# Altium schematic sheet FPGA_MISC.SchDoc(FPGA_MISC)
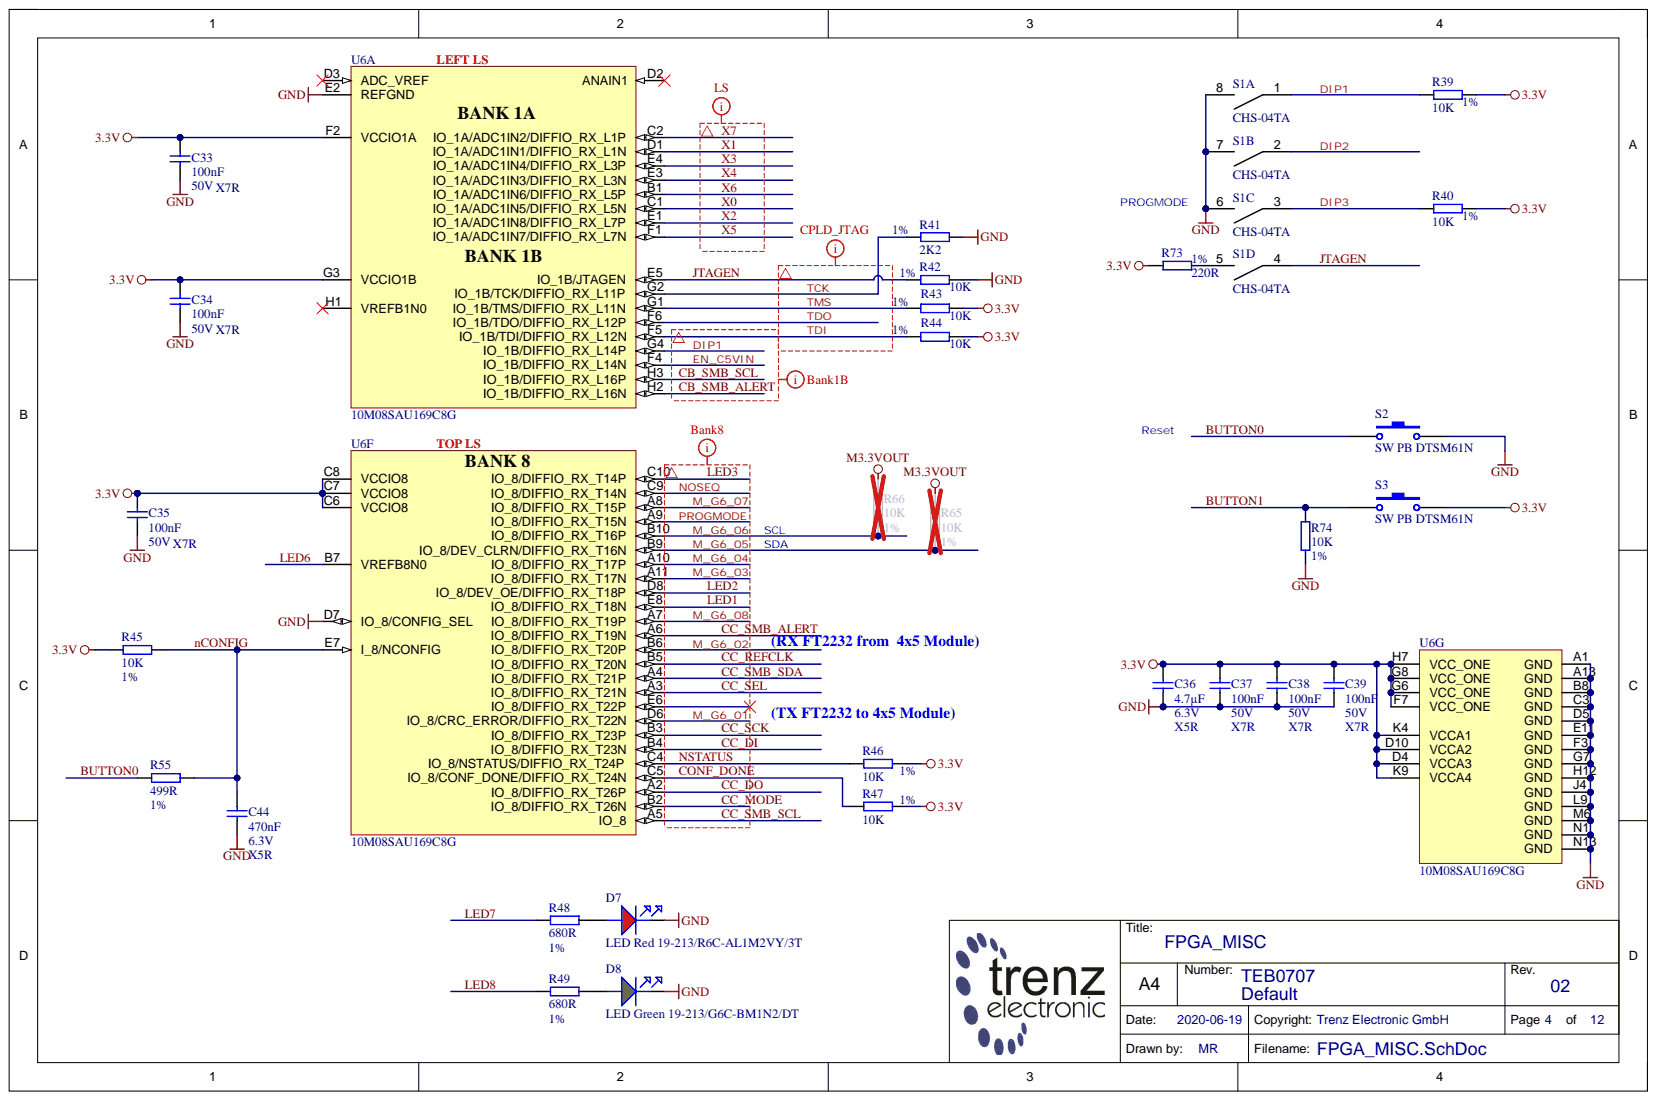
[diagram p0: FPGA_MISC.SchDoc]

## Parts
C33  100nF  Voltage: 50V  [CAPC1005X55N]
C34  100nF  Voltage: 50V  [CAPC1005X55N]
C35  100nF  Voltage: 50V  [CAPC1005X55N]
C36  4.7µF  Technology: X5R  Voltage: 6.3V  [CAPC1005X60N]
C37  100nF  Technology: X7R  Voltage: 50V  [CAPC1005X55N]
C38  100nF  Technology: X7R  Voltage: 50V  [CAPC1005X55N]
C39  100nF  Technology: X7R  Voltage: 50V  [CAPC1005X55N]
C44  470nF  Technology: X5R  Voltage: 6.3V  [CAPC1005X55N]
D7  LED Red 19-213/R6C-AL1M2VY/3T  Database Table Name: LED  H-ART: 19-213/R6C-AL1M2VY/3T  [LEDC1608X55N_RED]
D8  LED Green 19-213/G6C-BM1N2/DT  Database Table Name: LED  H-ART: 19-213/G6C-BM1N2/DT  [LEDC1608X55N_GREEN]
R39  10K  Tolerance: 1%  [RESC1005X40N]
R40  10K  Tolerance: 1%  [RESC1005X40N]
R41  2K2  Tolerance: 1%  [RESC1005X40N]
R42  10K  Tolerance: 1%  [RESC1005X40N]
R43  10K  Tolerance: 1%  [RESC1005X40N]
R44  10K  Tolerance: 1%  [RESC1005X40N]
R45  10K  Tolerance: 1%  [RESC1005X40N]
R46  10K  Tolerance: 1%  [RESC1005X40N]
R47  10K  Tolerance: 1%  [RESC1005X40N]
R48  680R  Tolerance: 1%  [RESC1005X40N]
R49  680R  Tolerance: 1%  [RESC1005X40N]
R55  499R  Tolerance: 1%  [RESC1005X40N]
R65  10K  Tolerance: 1%  [RESC1005X40N]
R66  10K  Tolerance: 1%  [RESC1005X40N]
R73  220R  Tolerance: 1%  [RESC1005X40N]
R74  10K  Tolerance: 1%  [RESC1005X40N]
S1  CHS-04TA  [CHS-04TA]  (parts A,B,C,D)
S2  SW PB DTSM61N  [DTSM61N]
S3  SW PB DTSM61N  [DTSM61N]
U6  10M08SAU169C8G  [BGA169C80P13X13_11X11X15]  (parts A,F,G)

## Nets
3.3V: C33.1 C34.1 C35.1 C36.1 C37.1 C38.1 C39.1 R39.1 R40.1 R43.2 R44.2 R45.1 R46.2 R47.2 R73.1 S3.2 U6.C6(VCCIO8) U6.C7(VCCIO8) U6.C8(VCCIO8) U6.D4(VCCA3) U6.D10(VCCA2) U6.F2(VCCIO1A) U6.F7(VCC_ONE) U6.G3(VCCIO1B) U6.G6(VCC_ONE) U6.G8(VCC_ONE) U6.H7(VCC_ONE) U6.K4(VCCA1) U6.K9(VCCA4)
GND: C33.2 C34.2 C35.2 C36.2 C37.2 C38.2 C39.2 C44.2 D7.K D8.K R41.2 R42.2 R74.1 S1.6 S1.7 S1.8 S2.2 U6.A1(GND) U6.A13(GND) U6.B8(GND) U6.C3(GND) U6.D5(GND) U6.D7(IO_8/CONFIG_SEL) U6.E2(REFGND) U6.E11(GND) U6.F3(GND) U6.G7(GND) U6.H12(GND) U6.J4(GND) U6.L9(GND) U6.M6(GND) U6.N1(GND) U6.N13(GND)
M3.3VOUT: R65.2 R66.2
BUTTON0: R55.1 S2.1
BUTTON1: R74.2 S3.1
CB_SMB_ALERT: U6.H2(IO_1B/DIFFIO_RX_L16N)
CB_SMB_SCL: U6.H3(IO_1B/DIFFIO_RX_L16P)
CC_DI: U6.B4(IO_8/DIFFIO_RX_T23N)
CC_DO: U6.A2(IO_8/DIFFIO_RX_T26P)
CC_MODE: U6.B2(IO_8/DIFFIO_RX_T26N)
CC_REFCLK: U6.B5(IO_8/DIFFIO_RX_T20N)
CC_SCK: U6.B3(IO_8/DIFFIO_RX_T23P)
CC_SEL: U6.A3(IO_8/DIFFIO_RX_T21N)
CC_SMB_ALERT: U6.A6(IO_8/DIFFIO_RX_T19N)
CC_SMB_SCL: U6.A5(IO_8)
CC_SMB_SDA: U6.A4(IO_8/DIFFIO_RX_T21P)
CONF_DONE: R47.1 U6.C5(IO_8/CONF_DONE/DIFFIO_RX_T24N)
DIP1: R39.2 S1.1 U6.G4(IO_1B/DIFFIO_RX_L14P)
DIP2: S1.2
DIP3: R40.2 S1.3
EN_C5VIN: U6.F4(IO_1B/DIFFIO_RX_L14N)
JTAGEN: R42.1 S1.4 U6.E5(IO_1B/JTAGEN)
LED1: U6.E8(IO_8/DIFFIO_RX_T18N)
LED2: U6.D8(IO_8/DEV_OE/DIFFIO_RX_T18P)
LED3: U6.C10(IO_8/DIFFIO_RX_T14P)
LED6: U6.B7(VREFB8N0)
LED7: R48.1
LED8: R49.1
M_G6_01: U6.D6(IO_8/CRC_ERROR/DIFFIO_RX_T22N)
M_G6_02: U6.B6(IO_8/DIFFIO_RX_T20P)
M_G6_03: U6.A11(IO_8/DIFFIO_RX_T17N)
M_G6_04: U6.A10(IO_8/DIFFIO_RX_T17P)
M_G6_05: R65.1 U6.B9(IO_8/DEV_CLRN/DIFFIO_RX_T16N)
M_G6_06: R66.1 U6.B10(IO_8/DIFFIO_RX_T16P)
M_G6_07: U6.A8(IO_8/DIFFIO_RX_T15P)
M_G6_08: U6.A7(IO_8/DIFFIO_RX_T19P)
nCONFIG: C44.1 R45.2 R55.2 U6.E7(I_8/NCONFIG)
NOSEQ: U6.C9(IO_8/DIFFIO_RX_T14N)
NSTATUS: R46.1 U6.C4(IO_8/NSTATUS/DIFFIO_RX_T24P)
PROGMODE: U6.A9(IO_8/DIFFIO_RX_T15N)
TCK: R41.1 U6.G2(IO_1B/TCK/DIFFIO_RX_L11P)
TDI: R44.1 U6.F5(IO_1B/TDI/DIFFIO_RX_L12N)
TDO: U6.F6(IO_1B/TDO/DIFFIO_RX_L12P)
TMS: R43.1 U6.G1(IO_1B/TMS/DIFFIO_RX_L11N)
X0: U6.C1(IO_1A/ADC1IN5/DIFFIO_RX_L5N)
X1: U6.D1(IO_1A/ADC1IN1/DIFFIO_RX_L1N)
X2: U6.E1(IO_1A/ADC1IN8/DIFFIO_RX_L7P)
X3: U6.E4(IO_1A/ADC1IN4/DIFFIO_RX_L3P)
X4: U6.E3(IO_1A/ADC1IN3/DIFFIO_RX_L3N)
X5: U6.F1(IO_1A/ADC1IN7/DIFFIO_RX_L7N)
X6: U6.B1(IO_1A/ADC1IN6/DIFFIO_RX_L5P)
X7: U6.C2(IO_1A/ADC1IN2/DIFFIO_RX_L1P)
NetD7_A: D7.A R48.2
NetD8_A: D8.A R49.2
NetR73_2: R73.2 S1.5

Not connected: U6 D2(ANAIN1) D3(ADC_VREF) E6(IO_8/DIFFIO_RX_T22P) H1(VREFB1N0)

## Library data of the parts
C33-C35 C37-C39 = 22651: KON 100nF 50V X7R ±10% SMD BF 1005 (0402); Database Table Name: C_1005_0402; Mixed: SMT; Temperature-Range: -55/+125; Value: 100n; AN: 22651; Case: 1005 (0402); Component Kind: Standard; Library Name: TE.DbLib; Tolerance: 10%
  C33-C35: Technology: X7R
C36 = 24719: KON 4.7µF 6.3V X5R 20% SMD BF 1005 (0402); Database Table Name: C_1005_0402; Mixed: SMT; Preferred: Yes; Temperature-Range: -55/+85; Value: 4.7u; AN: 24719; Case: 1005 (0402); Component Kind: Standard; Library Name: TE.DbLib; Tolerance: 20%
C44 = 23659: KON 470nF 6,3V X5R SMD BF 1005 (0402); Database Table Name: C_1005_0402; Mixed: SMT; Preferred: Yes; Temperature-Range: -55/+85; Value: 470n; AN: 23659; Case: 1005 (0402); Component Kind: Standard; Library Name: TE.DbLib
D7 = 28261: Chip LED 0603 Briliant Red; Extra: Led Red SMD 0603 , 19-213/R6C-AL1M2VY/3T; Mixed: SMT; AN: 28261; Case: 1608 (0603); Component Kind: Standard; Library Name: TE.DbLib; HelpURL: b:\AD\adlib\datasheet\19-213-R6C\
D8 = 28262: Chip LED 0603 Briliant Green; Extra: Led Green SMD 0603; Mixed: SMT; Temperature-Range: -40/+85 (Abs); AN: 28262; Case: 1608 (0603); Component Kind: Standard; Library Name: TE.DbLib; HelpURL: b:\AD\adlib\datasheet\19-213-G6C-BM1\
R39 R40 R42-R47 R65 R66 R74 = 22750: WID SMD 10K 1% 0,063W BF 1005 (0402); Database Table Name: R_1005_0402; H-ART: RC0402FR-0710KL; Mixed: SMT; Preferred: Yes; AN: 22750; Case: 1005 (0402); Component Kind: Standard; Library Name: TE.DbLib; Technology: TK100; Value: 10K
R41 = 22748: WID SMD 2K2 1% 0,063W BF 1005 (0402); Database Table Name: R_1005_0402; H-ART: RC0402FR-132K2L; Mixed: SMT; Preferred: Yes; Value: 2.2K; AN: 22748; Case: 1005 (0402); Component Kind: Standard; Library Name: TE.DbLib; Technology: TK100
R48 R49 = 22761: WID SMD 680R 1% 0,063W; Database Table Name: R_1005_0402; Extra: BF 1005 (0402); H-ART: RC0402FR-13680RL; Mixed: SMT; Preferred: Yes; Value: 680; AN: 22761; Case: 1005 (0402); Component Kind: Standard; Library Name: TE.DbLib; Technology: TK100
R55 = 27123: WID SMD 499R 1% 0,063W BF 1005 (0402); Database Table Name: R_1005_0402; Mixed: SMT; AN: 27123; Case: 1005 (0402); Component Kind: Standard; Library Name: TE.DbLib; Technology: TK100; Value: 499R
R73 = 22767: WID SMD 220R 1% 0,063W  BF 1005 (0402); Database Table Name: R_1005_0402; Mixed: SMT; Preferred: Yes; Value: 220; AN: 22767; Case: 1005 (0402); Component Kind: Standard; Library Name: TE.DbLib; Technology: TK100
S1 = 23417: DIP Schalter 4 fach 1,27mm SMD; Database Table Name: PB_SW; Mixed: SMT; Preferred: Yes; AN: 23417; Component Kind: Standard; Extra: CHS-04TA; H-ART: CHS-04TA; Library Name: TE.DbLib; Trenz-Stock: Yes; HelpURL: B:\AD\adlib\datasheet\CHS
S2 S3 = 22596: Taster Miniatur Leiterplatte 1.6N; Database Table Name: PB_SW; Extra: 4.3mm hoch, 6x6mm SMD, DTSM61N; Mixed: SMT; Preferred: Yes; AN: 22596; Component Kind: Standard; H-ART: DTSM61N; Library Name: TE.DbLib; Trenz-Stock: Yes; HelpURL: B:\AD\adlib\datasheet\DTSM
U6 = 27930: Database Table Name: PROG_LOGIC; Extra: Altera MAX10 FPGA 8k 130 I/O; Mixed: SMT; Temperature-Range: 0/+85 (Tj); AN: 27930; Case: LFBGA169; Component Kind: Standard; Description: 10M08SAU169C8G; H-ART: 10M08SAU169C8G; Library Name: TE.DbLib; Storage-Temperature: -65/+150(Abs); HelpURL: b:\AD\adlib\datasheet\ALTERA_MAX10\
